# Altium schematic sheet 08_Memory.SchDoc
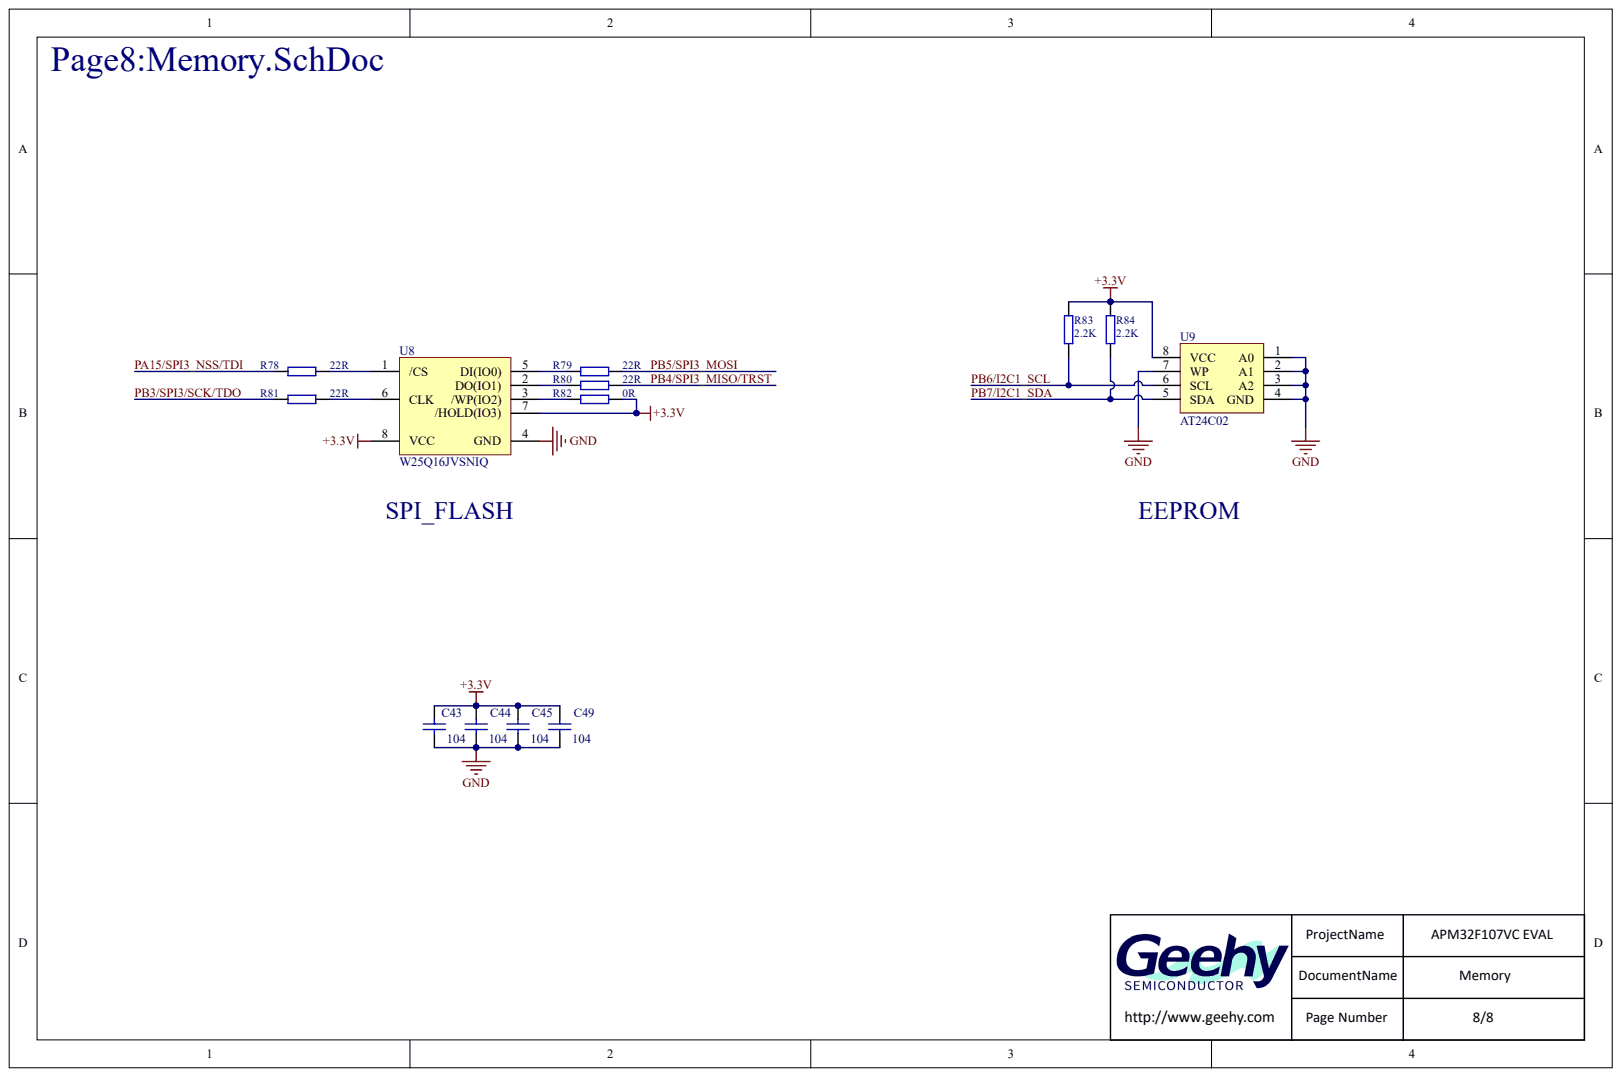
[diagram p0: 08_Memory.SchDoc]

## Parts
C43  104
C44  104
C45  104
C49  104
R78  22R
R79  22R
R80  22R
R81  22R
R82  0R
R83  2.2K
R84  2.2K
U8  W25Q16JVSNIQ
U9  AT24C02

## Nets
+3.3V: C43.2 C44.2 C45.2 C49.2 R82.1 R83.2 R84.2 U8.7(/HOLD(IO3)) U8.8(VCC) U9.8(VCC)
GND: C43.1 C44.1 C45.1 C49.1 U8.4(GND) U9.1(A0) U9.2(A1) U9.3(A2) U9.4(GND) U9.7(WP)
PA15/SPI3_NSS/TDI: R78.2
PB3/SPI3/SCK/TDO: R81.2
PB4/SPI3_MISO/TRST: R80.1
PB5/SPI3_MOSI: R79.1
PB6/I2C1_SCL: R83.1 U9.6(SCL)
PB7/I2C1_SDA: R84.1 U9.5(SDA)
NetR78_1: R78.1 U8.1(/CS)
NetR79_2: R79.2 U8.5(DI(IO0))
NetR80_2: R80.2 U8.2(DO(IO1))
NetR81_1: R81.1 U8.6(CLK)
NetR82_2: R82.2 U8.3(/WP(IO2))

## Library data of the parts
C43-C45 C49 = (no symbol name): Value: 100pF; Published: 8-Jun-2000; Revision: July-2002: Re-released for DXP Platform.; Publisher: Altium Limited
